# Altium schematic sheet IC.SchDoc
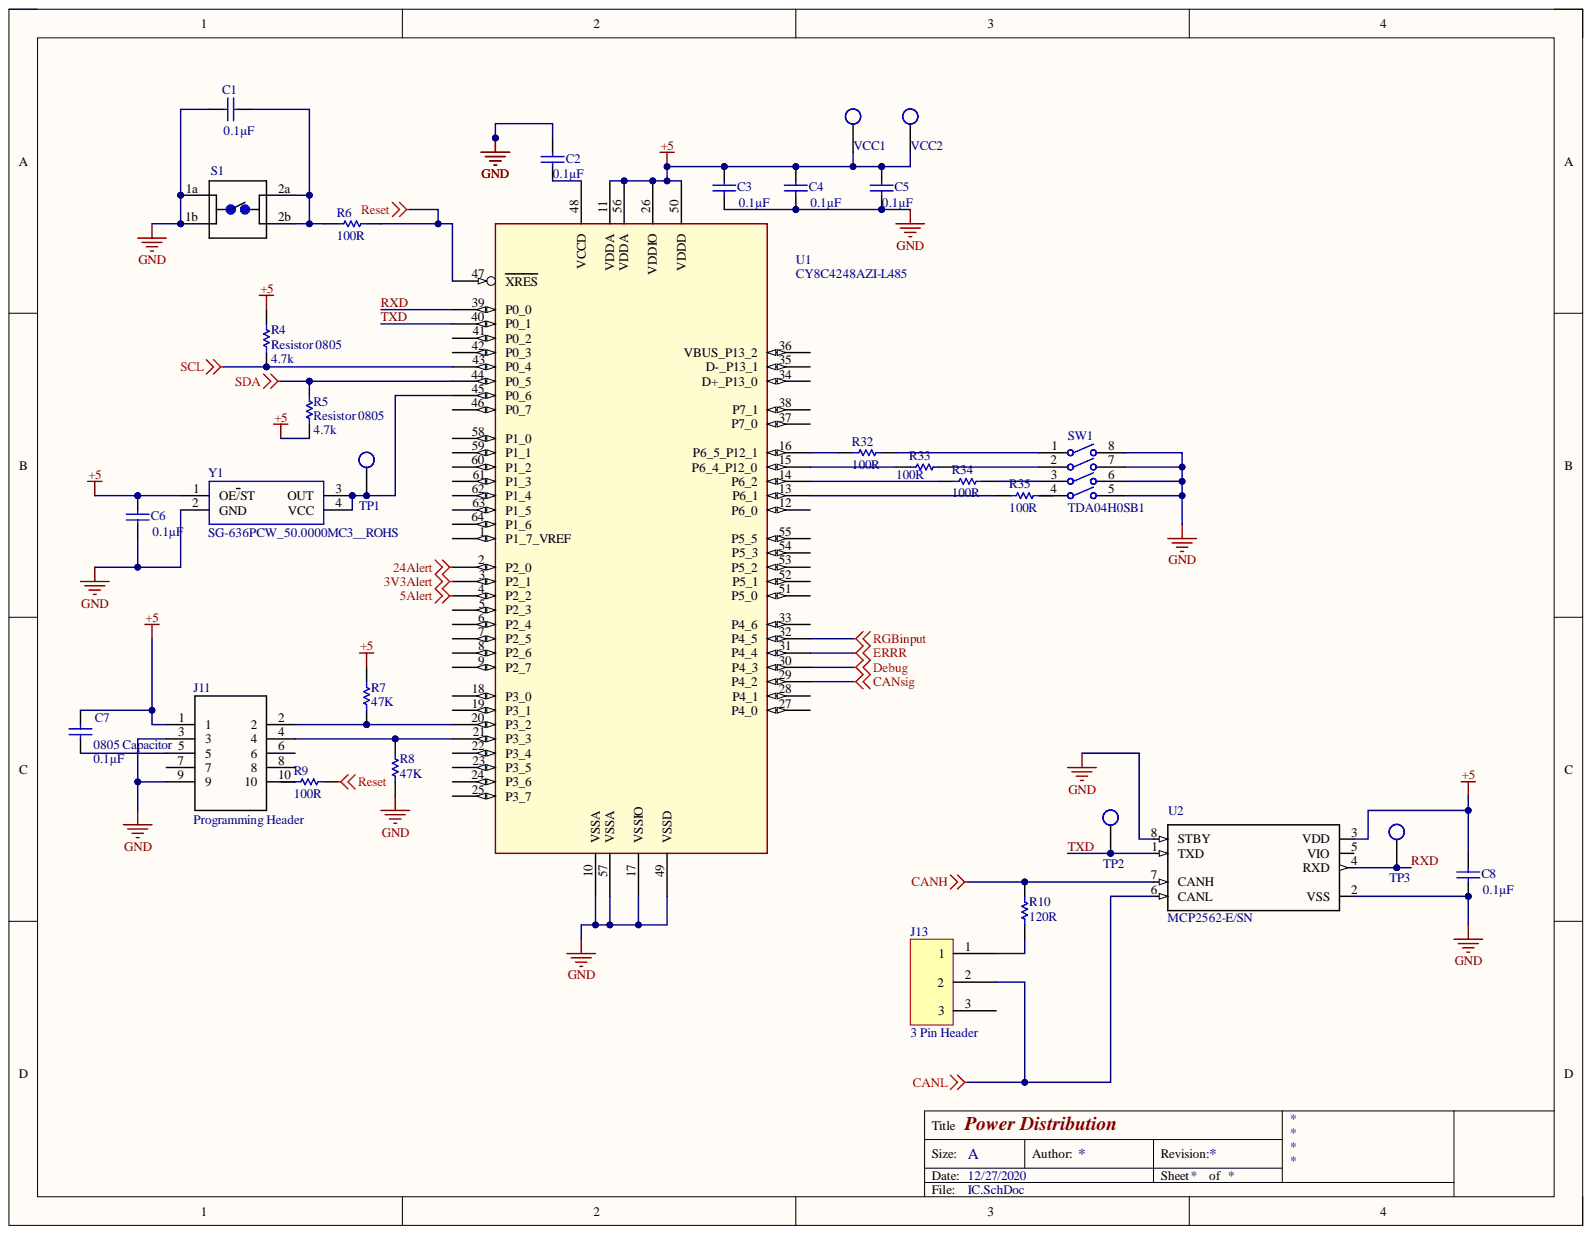
[diagram p0: IC.SchDoc]

## Parts
C1  0805 Capacitor  value 0.1µF  [Cap 0805]
C2  0805 Capacitor  value 0.1µF  [Cap 0805]
C3  0805 Capacitor  value 0.1µF  [Cap 0805]
C4  0805 Capacitor  value 0.1µF  [Cap 0805]
C5  0805 Capacitor  value 0.1µF  [Cap 0805]
C6  0805 Capacitor  value 0.1µF  [Cap 0805]
C7  0805 Capacitor  value 0.1µF  Case Size/Dimension: 0805  [Cap 0805]
C8  0805 Capacitor  value 0.1µF  [Cap 0805]
J11  Programming Header  [programming3D]
J13  3 Pin Header  [3 Pin Header]
R4  Resistor 0805  value 4.7k  [RESC0805(2012)_N]
R5  Resistor 0805  value 4.7k  [RESC0805(2012)_N]
R6  Resistor 0805  value 100R  [RESC0805(2012)_N]
R7  Resistor 0805  value 47K  [RESC0805(2012)_N]
R8  Resistor 0805  value 47K  [RESC0805(2012)_N]
R9  Resistor 0805  value 100R  [RESC0805(2012)_N]
R10  Resistor 0805  value 120R  [RESC0805(2012)_N]
R32  Resistor 0805  value 100R  [RESC0805(2012)_N]
R33  Resistor 0805  value 100R  [RESC0805(2012)_N]
R34  Resistor 0805  value 100R  [RESC0805(2012)_N]
R35  Resistor 0805  value 100R  [RESC0805(2012)_N]
S1  1825910-7  [Switch 6mm 24V (NO)]  (value not drawn)
SW1  TDA04H0SB1  [CKCP-TDA04H0SB1R_V]
TP1  Test Point  [Test Point Through-hole]  (value not drawn)
TP2  Test Point  [Test Point Through-hole]  (value not drawn)
TP3  Test Point  [Test Point Through-hole]  (value not drawn)
U1  CY8C4248AZI-L485  [TQFP50P1200X1200X160-64N]
U2  MCP2562-E/SN  [SOIC127P600X175-8N]
VCC1  Test Point  [Test Point Through-hole]  (value not drawn)
VCC2  Test Point  [Test Point Through-hole]  (value not drawn)
Y1  SG-636PCW_50.0000MC3__ROHS  [SG-636_3D]

## Nets
3V3Alert: U1.3(P2_1)
5Alert: C3.2 C4.2 C5.2 C6.2 C7.2 C8.2 J11.1 R4.2 R5.1 R7.2 U1.4(P2_2) U1.11(VDDA) U1.26(VDDIO) U1.50(VDDD) U1.56(VDDA) U2.3(VDD) VCC1.1 VCC2.1 Y1.1(OE/ST)
24Alert: U1.2(P2_0)
CANH: R10.2 U2.7(CANH)
CANL: J13.2 U2.6(CANL)
CANsig: U1.29(P4_2)
Debug: U1.30(P4_3)
ERRR: U1.31(P4_4)
GND: C1.1 C2.2 C3.1 C4.1 C5.1 C6.1 C8.1 J11.3 J11.9 R8.1 S1.1a S1.1b SW1.5 SW1.6 SW1.7 SW1.8 U1.10(VSSA) U1.17(VSSIO) U1.49(VSSD) U1.57(VSSA) U2.2(VSS) U2.8(STBY) Y1.2(GND)
Reset: R6.2 R9.2 U1.47(XRES)
RGBinput: U1.32(P4_5)
SCL: R4.1 U1.43(P0_4)
SDA: R5.2 U1.44(P0_5)
RXD: TP3.1 U1.39(P0_0) U2.4(RXD)
TXD: TP2.1 U1.40(P0_1) U2.1(TXD)
NetC1_2: C1.2 R6.1 S1.2a S1.2b
NetC2_1: C2.1 U1.48(VCCD)
NetC7_1: C7.1 J11.5
NetJ11_2: J11.2 R7.1 U1.20(P3_2)
NetJ11_4: J11.4 R8.2 U1.21(P3_3)
NetJ11_10: J11.10 R9.1
NetJ13_1: J13.1 R10.1
NetR32_1: R32.1 U1.16(P6_5_P12_1)
NetR32_2: R32.2 SW1.1
NetR33_1: R33.1 U1.15(P6_4_P12_0)
NetR33_2: R33.2 SW1.2
NetR34_1: R34.1 U1.14(P6_2)
NetR34_2: R34.2 SW1.3
NetR35_1: R35.1 U1.13(P6_1)
NetTP1_1: TP1.1 U1.45(P0_6) Y1.3(OUT) Y1.4(VCC)

Not connected: J11 6 7 8; J13 3; R35 2; SW1 4; U1 1(P1_7_VREF) 5(P2_3) 6(P2_4) 7(P2_5) 8(P2_6) 9(P2_7) 12(P6_0) 18(P3_0) 19(P3_1) 22(P3_4) 23(P3_5) 24(P3_6) 25(P3_7) 27(P4_0) 28(P4_1) 33(P4_6) 34(D+_P13_0) 35(D-_P13_1) 36(VBUS_P13_2) 37(P7_0) 38(P7_1) 41(P0_2) 42(P0_3) 46(P0_7) 51(P5_0) 52(P5_1) 53(P5_2) 54(P5_3) 55(P5_5) 58(P1_0) 59(P1_1) 60(P1_2) 61(P1_3) 62(P1_4) 63(P1_5) 64(P1_6); U2 5(VIO)

## Library data of the parts
C1-C8 = CMP-002-0000-1: Standard Capacitor; Tolerance (%): ±10%; Component Kind: Standard; Component Type: Standard; ComponentLink1Description: Digi-Key_Purchase_URL; ComponentLink1URL: https://www.digikey.com/product-detail/en/kemet/C0805C104Z5VACTU/399-1177-1-ND/411452; ComponentLink2Description: Datasheet Link; ComponentLink2URL: https://content.kemet.com/datasheets/KEM_C1005_Y5V_SMD.pdf; Design Item ID: 0805 Capacitor; Digi-Key_Part_Number: 399-1177-1-ND; Digikey URL: https://www.digikey.com/product-detail/en/kemet/C0805C104Z5VACTU/399-1177-1-ND/411452; Mounting Technology: SurfaceMount; Package Description: 2-Pin Surface Mount Device, Body 2.01 x 1.25 mm; Package Reference: 0805; Pin Count: 2; Source: Standard Cap.SchLib; Library Name: University of Washington - Husky Robotics Team
  C1-C6 C8: Case Size/Dimension: 0805
R4 R5 = CMP-006-0001-1: Generic 0805 Resistor; Case-EIA: 0805; Case-Metric: 2012; Power: 0.125 W; Tolerance: 5 %; Component Kind: Standard; Component Type: Standard; ComponentLink1Description: Digi-Key_Purchase_Link; ComponentLink1URL: https://www.digikey.com/product-detail/en/rohm-semiconductor/ESR10EZPJ121/RHM120KCT-ND/1762959; ComponentLink2Description: Datasheet Link; ComponentLink2URL: https://d1d2qsbl8m0m72.cloudfront.net/en/products/databook/datasheet/passive/resistor/chip_resistor/esr-e.pdf; Design Item ID: Resistor 0805; Digi-Key_Part_Number: RHM120KCT-ND; Pin Count: 2; Technology: SMT; Library Name: University of Washington - Husky Robotics Team; Source: CAN Termination Resistor 0805.SchLib
R6-R10 R32-R35 = Resistor 0805: Generic 0805 Resistor; Case-EIA: 0805; Case-Metric: 2012; Power: 0.125 W; Tolerance: 5 %; Component Kind: Standard; Technology: SMT; Library Name: Husky Robotics Standard Library 2019-2020.IntLib
  R32-R35: ComponentLink1Description: Digi-Key_Purchase_Link; ComponentLink1URL: https://www.digikey.com/product-detail/en/rohm-semiconductor/ESR10EZPJ121/RHM120KCT-ND/1762959; ComponentLink2Description: Datasheet Link; ComponentLink2URL: https://d1d2qsbl8m0m72.cloudfront.net/en/products/databook/datasheet/passive/resistor/chip_resistor/esr-e.pdf; Digi-Key_Part_Number: RHM120KCT-ND
S1 = Switch 6mm 24V (NO): FSM4JAH=6MM TACT SWITCH, HIGH TEMP; Configuration_Pole-Throw: Single Pole - Single Throw; Contact_Current_Rating: 50 mA; MF: TE Connectivity; Component Kind: Standard; Digi-Key_Part_Number: 450-1804-ND; Digi-Key_Purchase_URL: https://www.digikey.com/product-detail/en/te-connectivity-alcoswitch-switches/1825910-7/450-1804-ND/1731414?utm_source=snapeda&utm_medium=aggregator&utm_campaign=symbol; EU_RoHS_Compliance: Compliant; MP: 1825910-7; Package: None; SnapEDA_Link: https://www.snapeda.com/parts/1825910-7/TE%20Connectivity%20ALCOSWITCH%20Switches/view-part/?ref=snap; TE_purchase_URL: https://www.te.com/usa-en/product-1825910-7.html?te_bu=Cor&te_type=disp&te_campaign=seda_glo_cor-seda-global-disp-prtnr-fy19-seda-model-bom-cta_sma-317_1&elqCampaignId=32493; Library Name: Husky Robotics Standard Library 2019-2020.IntLib
SW1 = Dip Switch Array SMD Half-Pitch: Ultra-Miniature Surface Mount Half-Pitch DIP Switch, 25 mA, 24 V, -40 to 85 degC, 8-Pin SMD, RoHS, Tube; Contact Current Rating: 100 mA; Max Contact Resistance: 100 mohm; Max Current Rating: 25 mA; Max Voltage Rating (DC): 24 V; Throw Configuration: SPST; Case\Package: DIP; Component Kind: Standard; ComponentLink1Description: Datasheet; ComponentLink1URL: http://www.ck-components.com/media/1328/tda.pdf; Contact Finish: Gold; Contact Material: Copper; Contact Plating: Gold; Current Rating: 100 mA; DatasheetVersion: 02/2016; Height: 2.3 mm; Lead Pitch: 1.27 mm; Length: 6.21 mm; Max Operating Temperature: 85 degC; Min Operating Temperature: -40 degC; Mount: Surface Mount; Mounting Technology: Surface Mount; Package Quantity: 70; PackageDescription: 8-Pin Surface Mount Device, Body 6.21 x 6.2 mm, Pitch 1.27 mm; PackageReference: TDA04H0SB1R; Packaging: Rail/Tube; Pins: 4; Radiation Hardening: No; REACH SVHC: No SVHC; RoHSCompliant: true; Voltage Rating (DC): 24 V; Library Name: Husky Robotics Standard Library 2019-2020.IntLib
U1 = PSOC MC: 64 pin psoc; Component Kind: Standard; Part_Number: CY8C4248AZI-L485; Library Name: Husky Robotics Standard Library 2019-2020.IntLib
U2 = CAN Tranceiver: Microchip MCP2562-E/SN, CAN Transceiver 1MBps 1-channel IEC 61000-4-2, 8-Pin SOIC; Availability: Unavailable; Component Kind: Standard; MF: Microchip; MP: MCP2562-E/SN; Package: SO-8 Microchip; Price: None; SnapEDA_Link: https://www.snapeda.com/parts/MCP2562-E/SN/Microchip/view-part/?ref=snap; Library Name: Husky Robotics Standard Library 2019-2020.IntLib
Y1 = Oscilator: Standard Clock Oscillators; Manufacturer_Name: Epson Timing; Manufacturer_Part_Number: SG-636PCW 50.0000MC3: ROHS; Arrow Part Number: SG-636PCW 50.0000MC3: ROHS; Component Kind: Standard; Datasheet Link: http://www.mouser.com/ds/2/137/G-636PCE_en-1003252.pdf; Library Name: Husky Robotics Standard Library 2019-2020.IntLib
J11 = Programming Header: Component Kind: Standard; Library Name: Husky Robotics Standard Library 2019-2020.IntLib
J13 = 3 Pin Header: Component Kind: Standard; Library Name: Husky Robotics Standard Library 2019-2020.IntLib
TP1-TP3 VCC1 VCC2 = Test Point: Component Kind: Standard; Library Name: Husky Robotics Standard Library 2019-2020.IntLib
